# Altium schematic sheet IRM-AT.SchDoc
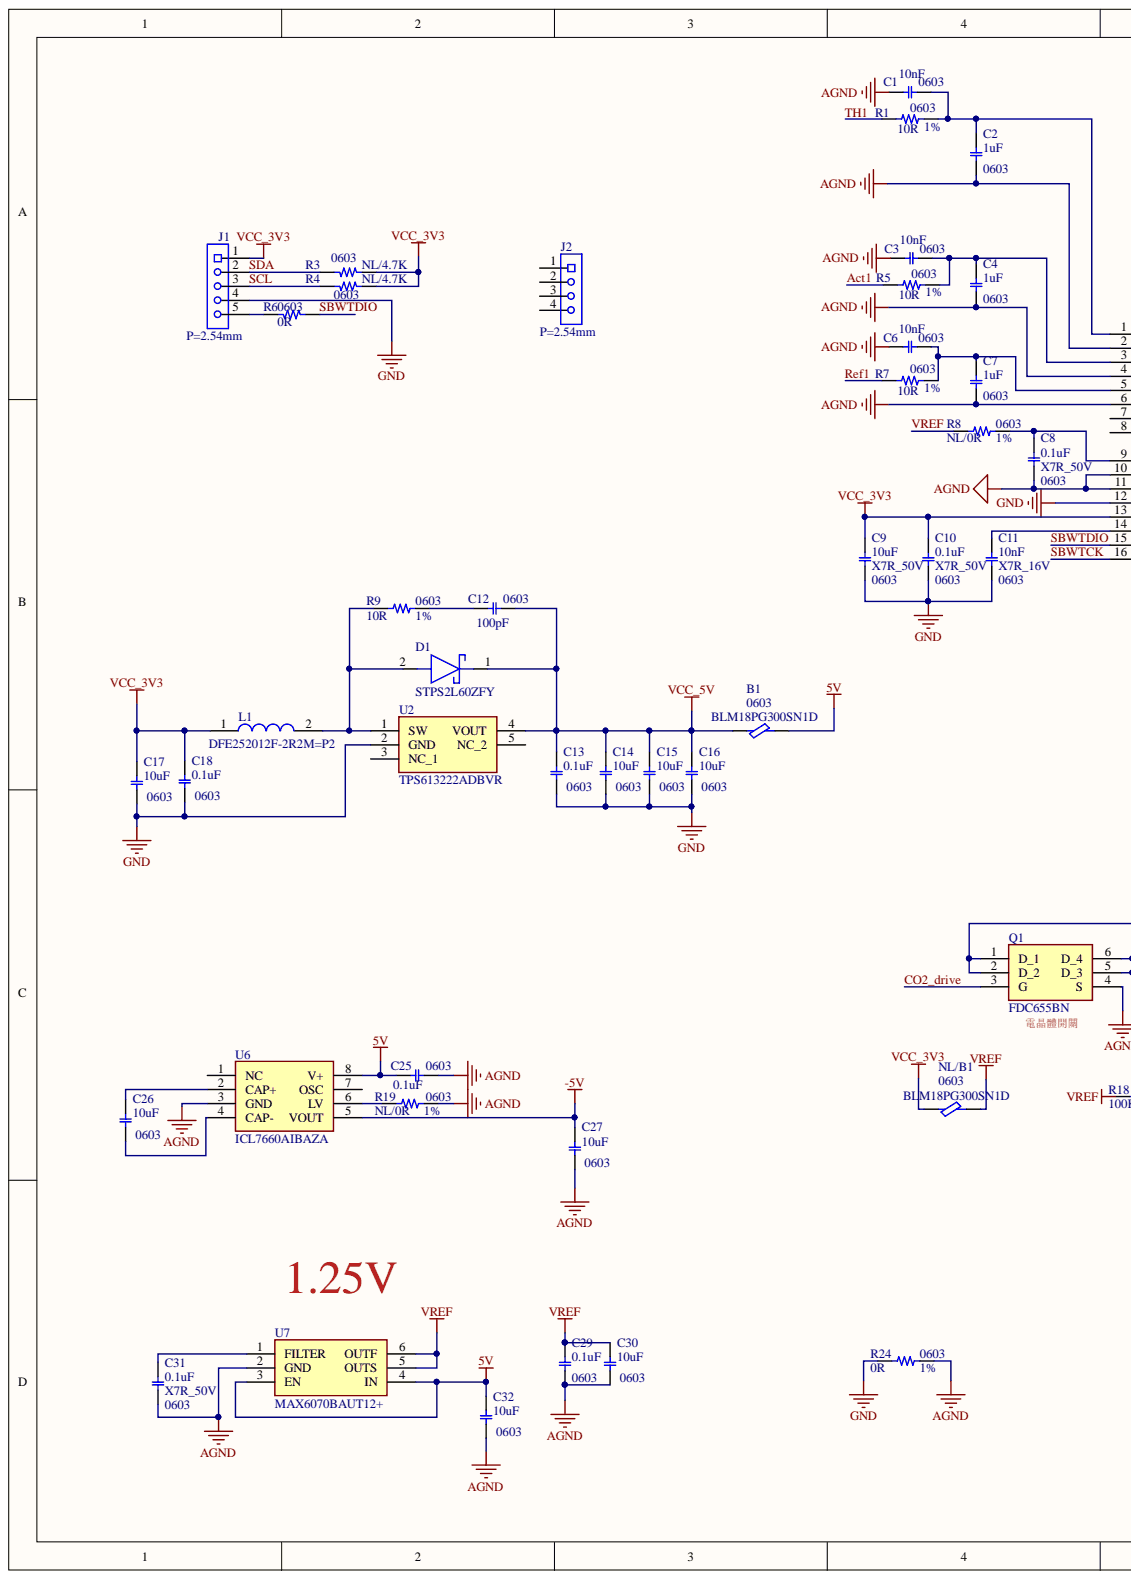
[diagram p0: IRM-AT.SchDoc, left]
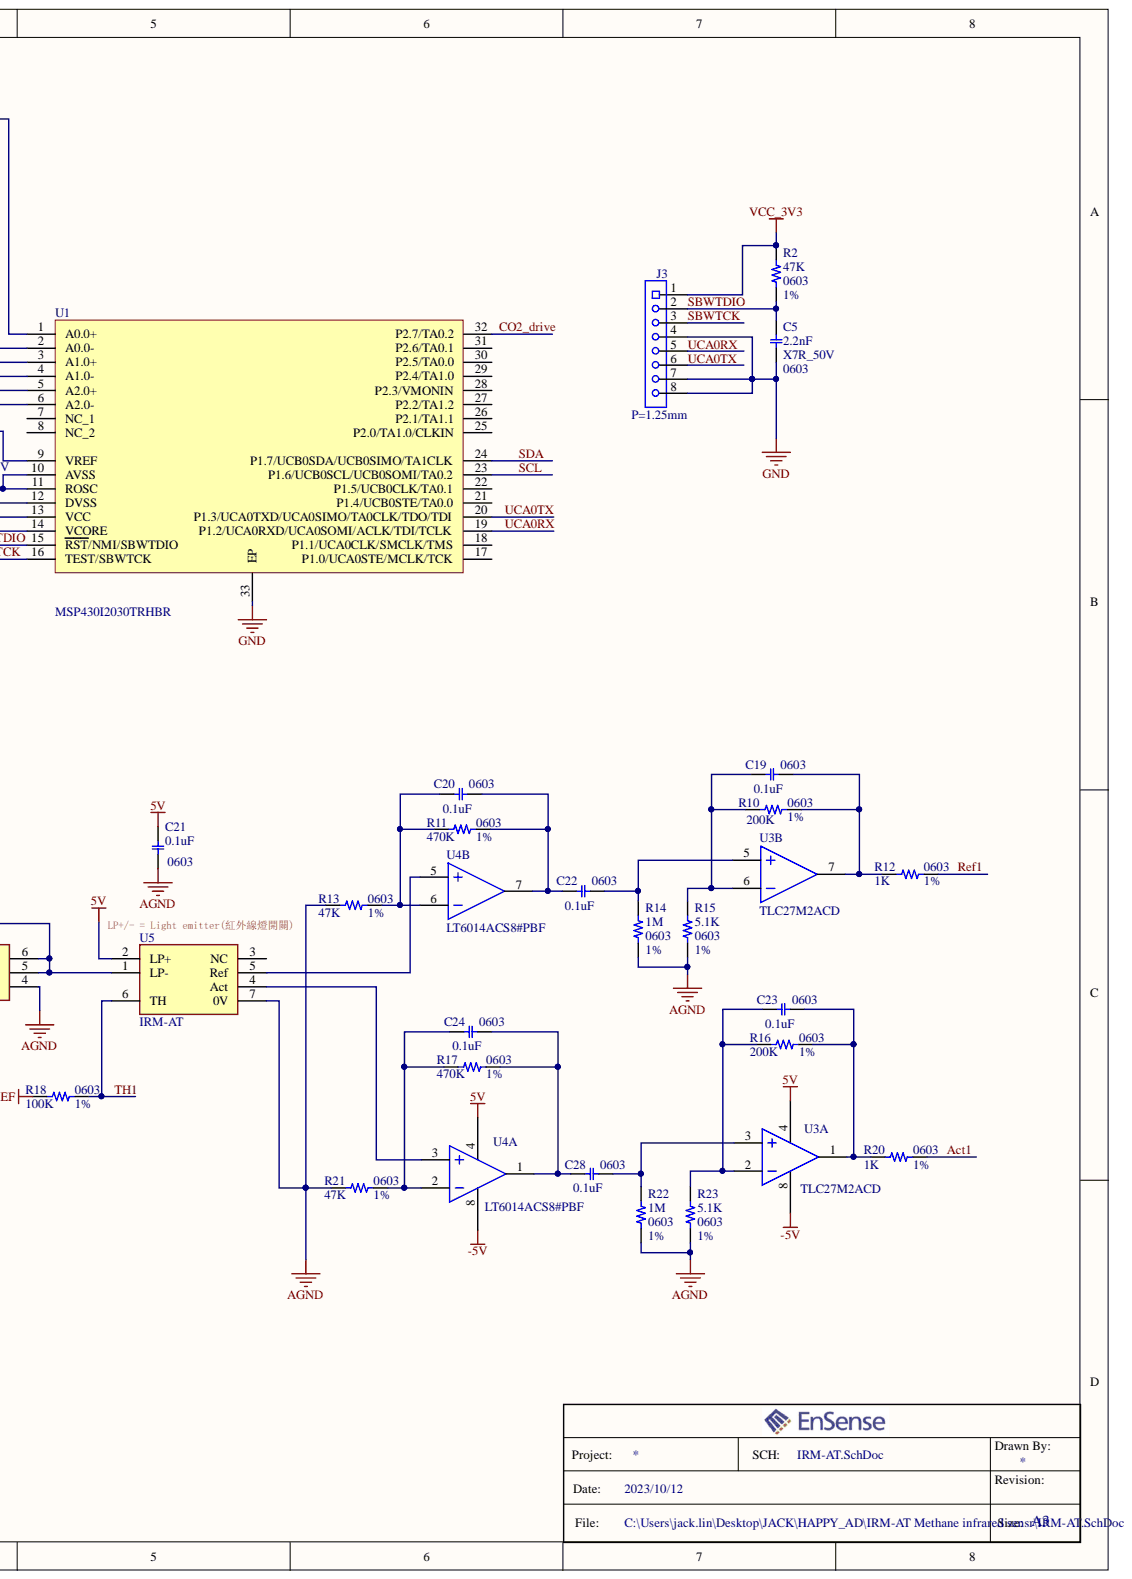
[diagram p1: IRM-AT.SchDoc, right]

## Parts
B1  Manufacture_Part_Number: BLM18PG300SN1D  Package: 0603  [CB0603]  (hidden comment: 30_100MHz)
C1  10nF  Package: 0603  [CC0603]
C2  1uF  Package: 0603  [CC0603]
C3  10nF  Package: 0603  [CC0603]
C4  1uF  Package: 0603  [CC0603]
C5  2.2nF  Characteristic: X7R_50V  Package: 0603  [CC0603]
C6  10nF  Package: 0603  [CC0603]
C7  1uF  Package: 0603  [CC0603]
C8  0.1uF  Characteristic: X7R_50V  Package: 0603  [CC0603]
C9  10uF  Characteristic: X7R_50V  Package: 0603  [CC0603]
C10  0.1uF  Characteristic: X7R_50V  Package: 0603  [CC0603]
C11  10nF  Characteristic: X7R_16V  Package: 0603  [CC0603]
C12  100pF  Package: 0603  [CC0603]
C13  0.1uF  Package: 0603  [CC0603]
C14  10uF  Package: 0603  [CC0603]
C15  10uF  Package: 0603  [CC0603]
C16  10uF  Package: 0603  [CC0603]
C17  10uF  Package: 0603  [CC0603]
C18  0.1uF  Package: 0603  [CC0603]
C19  0.1uF  Package: 0603  [CC0603]
C20  0.1uF  Package: 0603  [CC0603]
C21  0.1uF  Package: 0603  [CC0603]
C22  0.1uF  Package: 0603  [CC0603]
C23  0.1uF  Package: 0603  [CC0603]
C24  0.1uF  Package: 0603  [CC0603]
C25  0.1uF  Package: 0603  [CC0603]
C26  10uF  Package: 0603  [CC0603]
C27  10uF  Package: 0603  [CC0603]
C28  0.1uF  Package: 0603  [CC0603]
C29  0.1uF  Package: 0603  [CC0603]
C30  10uF  Package: 0603  [CC0603]
C31  0.1uF  Characteristic: X7R_50V  Package: 0603  [CC0603]
C32  10uF  Package: 0603  [CC0603]
D1  STPS2L60ZFY  [SODFL3516X110N]
J1  value P=2.54mm  [HDR1X5]  (hidden comment: PN1X05HM_P2.54)
J2  value P=2.54mm  [S-4P]  (hidden comment: PN1X04HM_P2.54)
J3  value P=1.25mm  [Molex-1.25 8P]  (hidden comment: MOLEX_1.25_8P)
L1  DFE252012F-2R2M=P2  [DFE2HCAH1R0MJ0L]
NL/B1  Manufacture_Part_Number: BLM18PG300SN1D  Package: 0603  [CB0603]  (hidden comment: 30_100MHz)
Q1  FDC655BN  [SOT95P280X110-6N]
R1  10R  Characteristic: 1%  Package: 0603  [CR0603]
R2  47K  Characteristic: 1%  Package: 0603  [CR0603]
R3  NL/4.7K  Package: 0603  [CR0603]
R4  NL/4.7K  Package: 0603  [CR0603]
R5  10R  Characteristic: 1%  Package: 0603  [CR0603]
R6  0R  Package: 0603  [CR0603]
R7  10R  Characteristic: 1%  Package: 0603  [CR0603]
R8  NL/0R  Characteristic: 1%  Package: 0603  [CR0603]
R9  10R  Characteristic: 1%  Package: 0603  [CR0603]
R10  200K  Characteristic: 1%  Package: 0603  [CR0603]
R11  470K  Characteristic: 1%  Package: 0603  [CR0603]
R12  1K  Characteristic: 1%  Package: 0603  [CR0603]
R13  47K  Characteristic: 1%  Package: 0603  [CR0603]
R14  1M  Characteristic: 1%  Package: 0603  [CR0603]
R15  5.1K  Characteristic: 1%  Package: 0603  [CR0603]
R16  200K  Characteristic: 1%  Package: 0603  [CR0603]
R17  470K  Characteristic: 1%  Package: 0603  [CR0603]
R18  100K  Characteristic: 1%  Package: 0603  [CR0603]
R19  NL/0R  Characteristic: 1%  Package: 0603  [CR0603]
R20  1K  Characteristic: 1%  Package: 0603  [CR0603]
R21  47K  Characteristic: 1%  Package: 0603  [CR0603]
R22  1M  Characteristic: 1%  Package: 0603  [CR0603]
R23  5.1K  Characteristic: 1%  Package: 0603  [CR0603]
R24  0R  Characteristic: 1%  Package: 0603  [CR0603]
U1  MSP430I2030TRHBR  [QFN50P500X500X100-33N-D]
U2  TPS613222ADBVR  [SOT95P280X145-5N]
U3  TLC27M2ACD  [SOIC127P600X175-8N]  (parts A,B)
U4  LT6014ACS8#PBF  [SOIC127P599X175-8N]  (parts A,B)
U5  IRM-AT  [IRM-AT]
U6  ICL7660AIBAZA  [SOIC127P600X175-8N]
U7  MAX6070BAUT12+  [SOT95P280X145-6N]

## Nets
5V: B1.2 C21.2 C25.2 C32.2 U3.4 U4.4 U5.2 U6.8 U7.3 U7.4
-5V: C27.2 U3.8 U4.8 U6.5
AGND: C1.2 C2.1 C3.2 C4.1 C6.2 C7.1 C8.1 C21.1 C25.1 C27.1 C29.1 C30.1 C31.1 C32.1 Q1.4 R13.1 R14.2 R15.2 R19.2 R21.1 R22.2 R23.2 R24.2 U1.2 U1.4 U1.6 U1.10 U1.11 U5.7 U6.3 U7.2
GND: C5.1 C9.1 C10.1 C11.1 C13.1 C14.1 C15.1 C16.1 C17.1 C18.1 J1.4 J3.4 J3.7 J3.8 R24.1 U1.12 U1.33 U2.2
VCC_3V3: C9.2 C10.2 C17.2 C18.2 J1.1 J3.1 L1.1 NL/B1.1 R2.1 R3.2 R4.2 U1.13
VCC_5V: B1.1 C12.1 C13.2 C14.2 C15.2 C16.2 D1.1 U2.4
Act1: R5.1 R20.2
CO2_drive: Q1.3 U1.32
Ref1: R7.1 R12.2
SBWTCK: J3.3 U1.16
SBWTDIO: C5.2 J3.2 R2.2 R6.2 U1.15
SCL: J1.3 R4.1 U1.23
SDA: J1.2 R3.1 U1.24
TH1: R1.1 R18.2 U5.6
UCA0RX: J3.5 U1.19
UCA0TX: J3.6 U1.20
VREF: C29.2 C30.2 NL/B1.2 R8.1 R18.1 U7.5 U7.6
NetC1_1: C1.1 C2.2 R1.2 U1.1
NetC3_1: C3.1 C4.2 R5.2 U1.3
NetC6_1: C6.1 C7.2 R7.2 U1.5
NetC8_2: C8.2 R8.2 U1.9
NetC11_2: C11.2 U1.14
NetC12_2: C12.2 R9.2
NetC19_1: C19.1 R10.2 R12.1 U3.7
NetC19_2: C19.2 R10.1 R15.1 U3.6
NetC20_1: C20.1 C22.2 R11.2 U4.7
NetC20_2: C20.2 R11.1 R13.2 U4.6
NetC22_1: C22.1 R14.1 U3.5
NetC23_1: C23.1 R16.2 R20.1 U3.1
NetC23_2: C23.2 R16.1 R23.1 U3.2
NetC24_1: C24.1 C28.2 R17.2 U4.1
NetC24_2: C24.2 R17.1 R21.2 U4.2
NetC26_1: C26.1 U6.4
NetC26_2: C26.2 U6.2
NetC28_1: C28.1 R22.1 U3.3
NetC31_2: C31.2 U7.1
NetD1_2: D1.2 L1.2 R9.1 U2.1
NetJ1_5: J1.5 R6.1
NetQ1_1: Q1.1 Q1.2 Q1.5 Q1.6 U5.1
NetR19_1: R19.1 U6.6
NetU4_3: U4.3 U5.4
NetU4_5: U4.5 U5.5

Not connected: J2 1 2 3 4; U1 7 8 17 18 21 22 25 26 27 28 29 30 31; U2 3 5; U5 3; U6 1 7

## Library data of the parts
B1 NL/B1 = ChipBead: CHIP BEAD; Manufacture: 台灣村田股份有限公司(Taiwan Murata Electronics Co., Ltd.); Part_Number: 3DLB-003025-000R; Characteristic: 1A; CLASS: DISCRETE; ProcessType: SMT; Tolerance: 25%; Component Kind: Standard; Library Name: ES_passive.SCHLIB
C1-C32 = ChipCap: MLCC; AVL_Part: AVL; Manufacture: 華新科技股份有限公司(Walsin Technology Corporation)||台灣東電化股份有限公司(TDK Taiwan Corporation)||台灣太陽誘電(股)公司(Taiwan  Taiyo Yuden  Co., Ltd.); Manufacture_Part_Number: 0603X105K250CT||C1608X5R1E105KT||TMK107BJ105KA; Part_Number: 1100007912; CLASS: DISCRETE; ProcessType: SMT; Tolerance: 10%; Component Kind: Standard; Library Name: ES_passive.SCHLIB
D1 = STPS2L60ZFY: Schottky Diode; Manufacturer_Name: STMicroelectronics; Arrow Part Number: STPS2L60ZFY; Arrow Price/Stock: https://www.arrow.com/en/products/stps2l60zfy/stmicroelectronics?region=nac; Component Kind: Standard; Datasheet Link: https://componentsearchengine.com/Datasheets/2/STPS2L60ZFY.pdf; Height: 1.1mm; Manufacturer_Part_Number: STPS2L60ZFY; Mouser Part Number: 511-STPS2L60ZFY; Mouser Price/Stock: https://www.mouser.co.uk/ProductDetail/STMicroelectronics/STPS2L60ZFY?qs=xjeEZJU9OeNqm6%252BLIddycA%3D%3D
J1 = PN1X05HM_P2.54: PN1x05, P=2.54mm; 料號: CN6-V1P04-000; Component Kind: Standard; Library Name: ES_connector.SCHLIB
J2 = PN1X04HM_P2.54: PN1x04,180度,P=2.54mm; 料號: CN6-V1P04-000; Component Kind: Standard; Library Name: ES_connector.SCHLIB
J3 = MOLEX_1.25_8P: MOLEX 1.25MM, 8P; 料號: CN6-V1P04-000; Component Kind: Standard; Library Name: ES_connector.SCHLIB
L1 = DFE252012F-2R2M=P2: Inductor; Manufacturer_Name: Murata Electronics; Arrow Part Number: DFE252012F-2R2M=P2; Arrow Price/Stock: https://www.arrow.com/en/products/dfe252012f-2r2mp2/murata-manufacturing?region=nac; Component Kind: Standard; Datasheet Link: https://psearch.en.murata.com/inductor/product/DFE252012F-2R2M%23.html; Height: 1.2mm; Manufacturer_Part_Number: DFE252012F-2R2M=P2; Mouser Part Number: 81-DFE252012F-2R2MP2; Mouser Price/Stock: https://www.mouser.co.uk/ProductDetail/Murata-Electronics/DFE252012F-2R2M%3dP2?qs=ty6qhqyUUEuYhdA0a9%2F0aw%3D%3D
Q1 = FDC655BN: MOSFET (N-Channel); Manufacturer_Name: onsemi; Arrow Part Number: FDC655BN; Arrow Price/Stock: https://www.arrow.com/en/products/fdc655bn/on-semiconductor?region=nac; Component Kind: Standard; Datasheet Link: https://www.onsemi.com/pub/Collateral/FDC655BN-D.PDF; Height: 1.1mm; Manufacturer_Part_Number: FDC655BN; Mouser Part Number: 512-FDC655BN; Mouser Price/Stock: https://www.mouser.co.uk/ProductDetail/onsemi-Fairchild/FDC655BN?qs=hCDsLQkP0Q%252BtcIKdoQVMcg%3D%3D
R1 R2 R5 R7-R24 = ChipRes: Chip Resistor; AVL_Part: AVL; Manufacture: 厚聲電子工業有限公司(Uniroyal Electronics Industry Co.,Ltd.)||國巨股份有限公司(Yageo Co.,Ltd.)||大毅科技股份有限公司(Ta-I Technolongy Co., Ltd.); Manufacture_Part_Number: 0603WAF2200T5E||RC0603FR-07220RL||RM06FTN2200; Part_Number: 105A522003; CLASS: DISCRETE; ProcessType: SMT; Component Kind: Standard; Library Name: ES_passive.SCHLIB; Tolerance: 1%
R3 R4 R6 = ChipRes: Chip Resistor; AVL_Part: AVL; Manufacture: 厚聲電子工業有限公司(Uniroyal Electronics Industry Co.,Ltd.)||國巨股份有限公司(Yageo Co.,Ltd.)||大毅科技股份有限公司(Ta-I Technolongy Co., Ltd.); Manufacture_Part_Number: 0603WAF2200T5E||RC0603FR-07220RL||RM06FTN2200; Part_Number: 105A522003; Characteristic: 1%_1/10W; CLASS: DISCRETE; ProcessType: SMT; Tolerance: 1%; Component Kind: Standard; Library Name: ES_passive.SCHLIB
U1 = MSP430I2030TRHBR: Integrated Circuit; Manufacturer_Name: Texas Instruments; Arrow Part Number: MSP430I2030TRHBR; Arrow Price/Stock: https://www.arrow.com/en/products/msp430i2030trhbr/texas-instruments; Component Kind: Standard; Datasheet Link: https://www.ti.com/lit/ds/symlink/msp430i2030.pdf?ts=1628678738994&ref_url=https%253A%252F%252Fwww.ti.com%252Fstore%252Fti%252Fen%252Fp%252Fproduct%252F%253Fp%253DMSP430I2030TRHBR; Height: 1mm; Library Name: SamacSys.SchLib; Manufacturer_Part_Number: MSP430I2030TRHBR; Mouser Part Number: 595-MSP430I2030TRHBR; Mouser Price/Stock: https://www.mouser.com/Search/Refine.aspx?Keyword=595-MSP430I2030TRHBR
U2 = TPS613222ADBVR: Integrated Circuit; Manufacturer_Name: Texas Instruments; Arrow Part Number: TPS613222ADBVR; Arrow Price/Stock: https://www.arrow.com/en/products/tps613222adbvr/texas-instruments?region=nac; Component Kind: Standard; Datasheet Link: http://www.ti.com/lit/gpn/tps61322; Height: 1.45mm; Manufacturer_Part_Number: TPS613222ADBVR; Mouser Part Number: 595-TPS613222ADBVR; Mouser Price/Stock: https://www.mouser.co.uk/ProductDetail/Texas-Instruments/TPS613222ADBVR?qs=W0yvOO0ixfE4d69WXsn8NQ%3D%3D
U3 = TLC27M2ACD: Integrated Circuit; Manufacturer_Name: Texas Instruments; Component Kind: Standard; Datasheet Link: http://www.ti.com/lit/gpn/tlc27m2a; Height: 1.75mm; Library Name: SamacSys.SchLib; Manufacturer_Part_Number: TLC27M2ACD; Mouser Part Number: 595-TLC27M2ACD; Mouser Price/Stock: https://www.mouser.co.uk/ProductDetail/Texas-Instruments/TLC27M2ACD?qs=afYny40WCj0iNXXRvfK6Pg%3D%3D
U4 = LT6014ACS8#PBF: Integrated Circuit; Manufacturer_Name: Analog Devices; Component Kind: Standard; Datasheet Link: https://www.analog.com/media/en/technical-documentation/data-sheets/60134fb.pdf; Height: 1.752mm; Library Name: SamacSys.SchLib; Manufacturer_Part_Number: LT6014ACS8#PBF; Mouser Part Number: 584-LT6014ACS8#PBF; Mouser Price/Stock: https://www.mouser.co.uk/ProductDetail/Analog-Devices/LT6014ACS8PBF?qs=hVkxg5c3xu8SEC4VLMXosA%3D%3D
U6 = ICL7660AIBAZA: Integrated Circuit; Manufacturer_Name: Intersil; Arrow Part Number: ICL7660AIBAZA; Arrow Price/Stock: https://www.arrow.com/en/products/icl7660aibaza/intersil; Component Kind: Standard; Datasheet Link: https://datasheet.datasheetarchive.com/originals/distributors/Datasheets-15/DSA-290970.pdf; Height: 1.75mm; Manufacturer_Part_Number: ICL7660AIBAZA; Mouser Part Number: 968-ICL7660AIBAZA
U7 = MAX6070BAUT12+: Integrated Circuit; Manufacturer_Name: Analog Devices; Component Kind: Standard; Datasheet Link: https://www.analog.com/MAX6070/datasheet; Height: 1.45mm; Manufacturer_Part_Number: MAX6070BAUT12+; Mouser Part Number: N/A; Mouser Price/Stock: https://www.mouser.co.uk/ProductDetail/Maxim-Integrated/MAX6070BAUT12%2b?qs=W%2FMpXkg%252BdQ7ArygRmS5l5g%3D%3D
U5 = IRM-AT: Component Kind: Standard; Library Name: SamacSys.SchLib
